# Altium schematic sheet STO.SchDoc(STO)
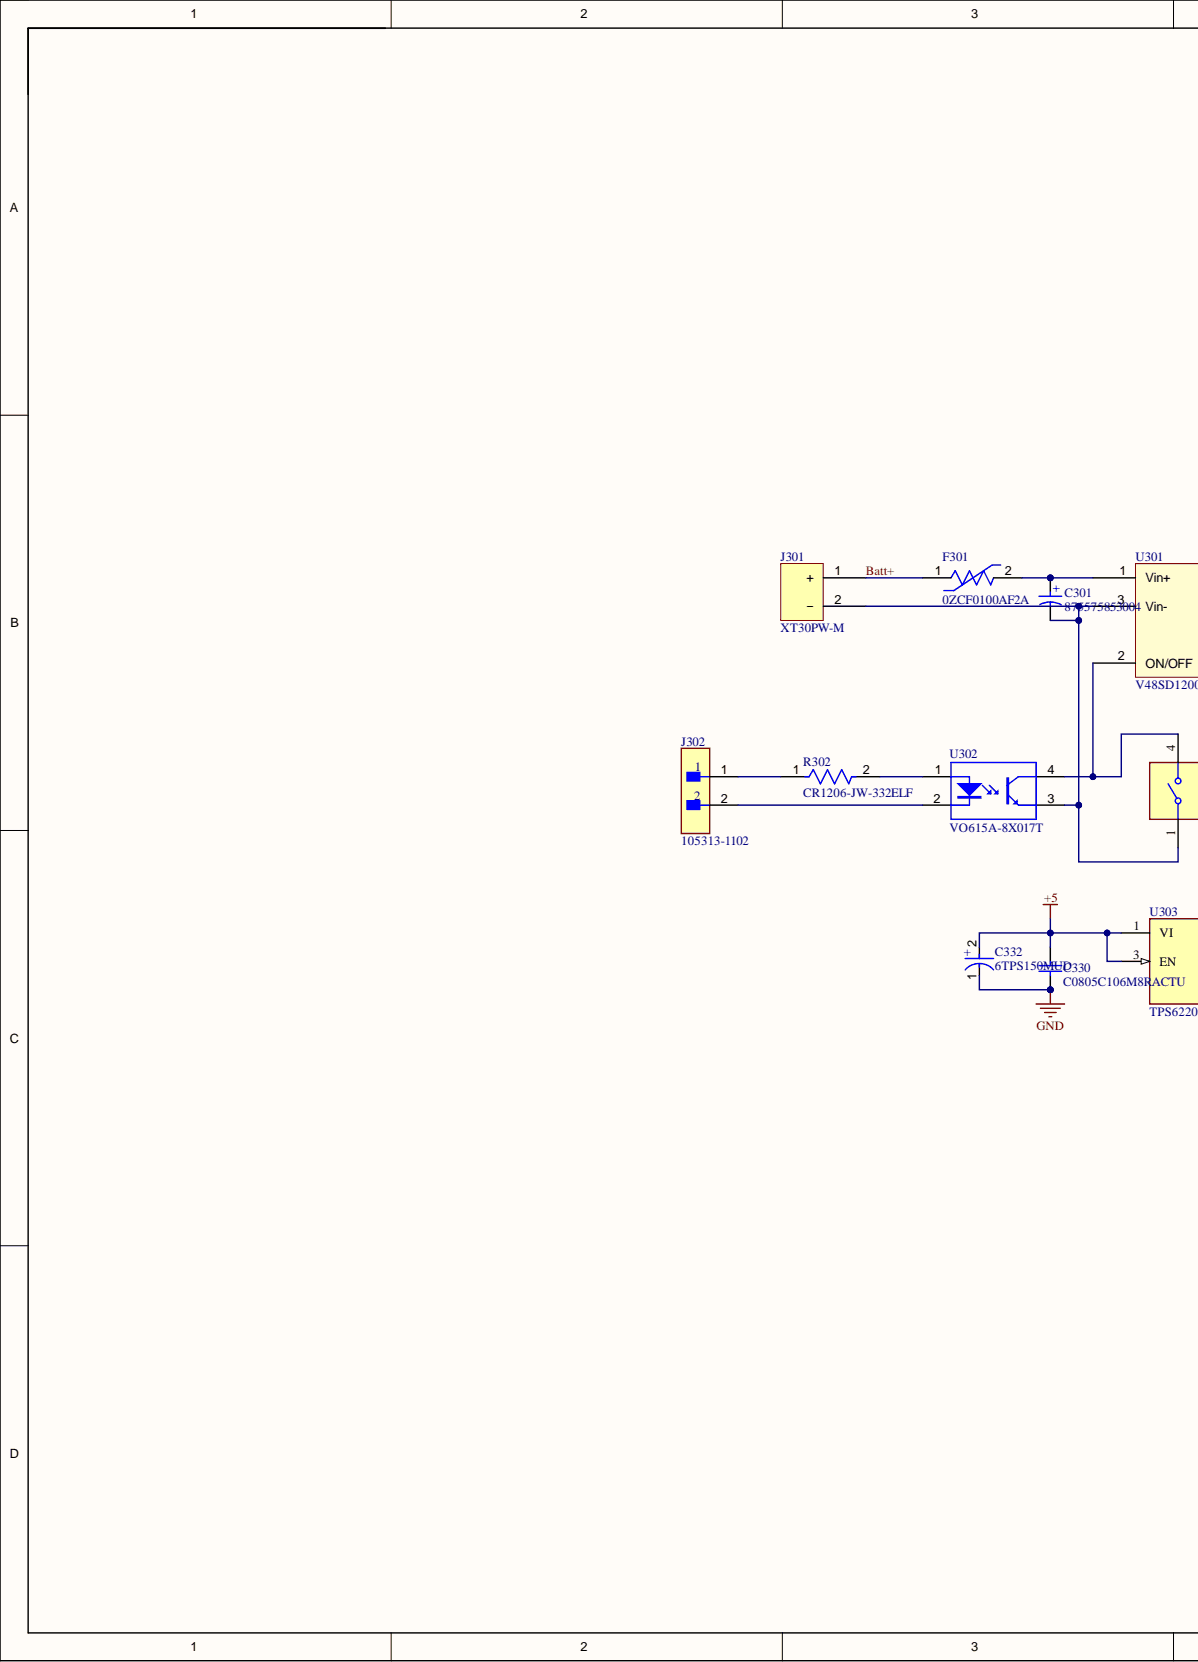
[diagram p0: STO.SchDoc, left]
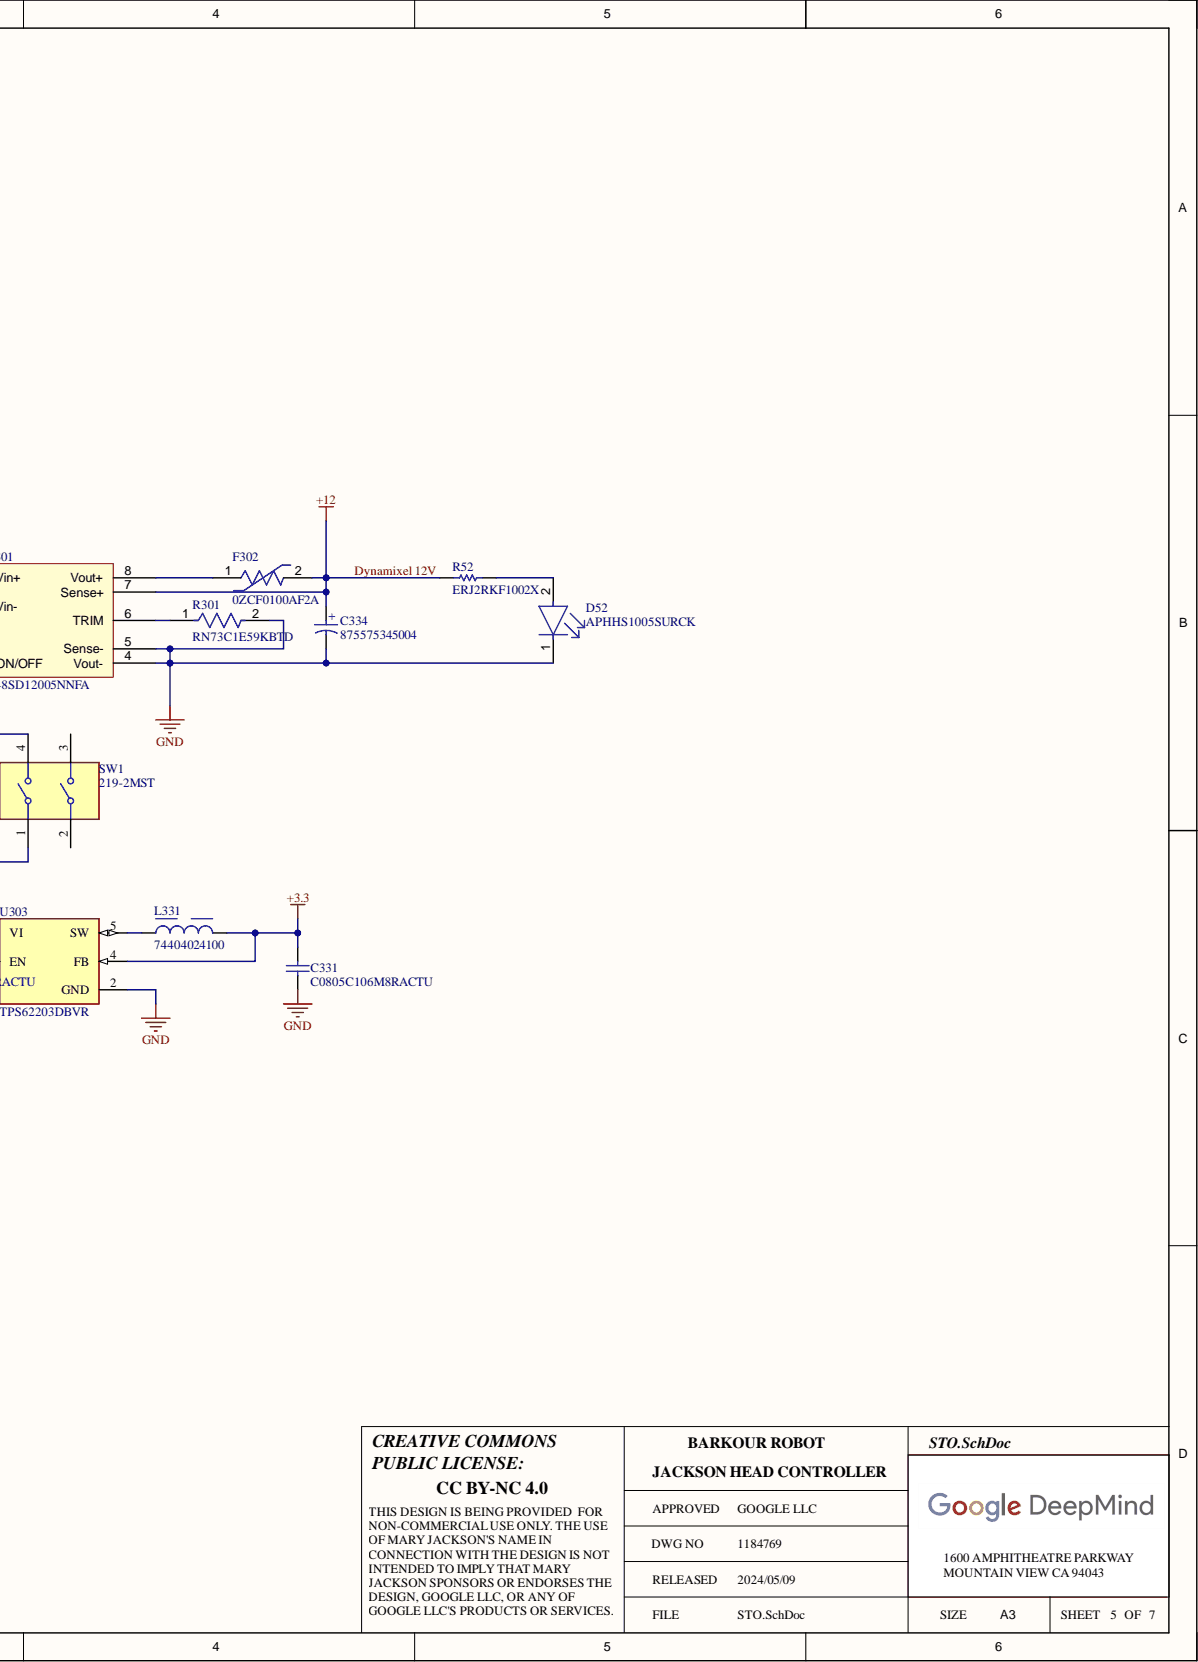
[diagram p1: STO.SchDoc, right]

## Parts
C301  875575853004  [WCAP-HSG5_D8H10.5]
C330  C0805C106M8RACTU  [CAPC2013X140X50NL20T25]
C331  C0805C106M8RACTU  [CAPC2013X140X50NL20T25]
C332  6TPS150MUD  [FP-POSCAP-B1S-MFG]
C334  875575345004  [WCAP-HSG5_D6.3H7.7]
D52  APHHS1005SURCK  [FP-APHHS1005SURCK-MFG]
F301  0ZCF0100AF2A  [FP-0ZCF0100AF2A-MFG]
F302  0ZCF0100AF2A  [FP-0ZCF0100AF2A-MFG]
J301  XT30PW-M  [XT30PW_M]
J302  105313-1102  [FP-105313-1102-MFG]
L331  74404024100  [WE-LQS_2512]
R52  ERJ2RKF1002X  [RESC1005X40X25LL05T05]
R301  RN73C1E59KBTD  [FP-RN731E-MFG]
R302  CR1206-JW-332ELF  [FP-CR1206-IPC_B]
SW1  219-2MST  [FP-219-2MST-MFG]
U301  V48SD12005NNFA  [1/16 Brick]
U302  VO615A-8X017T  [VISH-VO615A-XX017T_V]
U303  TPS62203DBVR  [FP-DBV0005A-IPC_B]

## Nets
+3.3: C331.2 L331.2 U303.4(FB)
+5: C330.1 C332.2 U303.1(VI) U303.3(EN)
GND: C330.2 C331.1 C332.1 C334.2 D52.1 R301.2 U301.4(Vout-) U301.5(Sense-) U303.2(GND)
Batt+: F301.1 J301.1
Dynamixel 12V: C334.1 F302.2 R52.2 U301.7(Sense+)
NetC301_1: C301.1 F301.2 U301.1(Vin+)
NetC301_2: C301.2 J301.2 SW1.1 U301.3(Vin-) U302.3
NetD52_2: D52.2 R52.1
NetF302_1: F302.1 U301.8(Vout+)
NetJ302_1: J302.1 R302.1
NetJ302_2: J302.2 U302.2
NetL331_1: L331.1 U303.5(SW)
NetR301_1: R301.1 U301.6(TRIM)
NetR302_2: R302.2 U302.1
NetSW1_4: SW1.4 U301.2(ON/OFF) U302.4

Not connected: SW1 2 3

## Library data of the parts
C301 = CMP-03913-134709168-1: 875575853004 Cap, 47Uf, 63V, Alu Elec, Hybrid, Smd Rohs Compliant: Yes; Capacitance: 47µF; ESR (Equivalent Series Resistance): 40mΩ; Leakage Current: 29.6µA; Ripple Current: 1.7A; Component Kind: Standard; Diameter: 8mm; Length: 10.5mm; Library Name: Altium Content Vault; Max Operating Temperature: 105°C; Min Operating Temperature: -55°C; NexarPartId: 134709168; RoHS: Compliant; Voltage Rating: 63V; Width: 8.3mm
C330 C331 = CMP-2007-03245-1: Manufacturer: Kemet; Component Kind: Standard; Library Name: Altium Content Vault; Part Number: C0805C106M8RACTU
C332 = CMP-05428-000345-1: Molded Tantalum Polymer Capacitor 150uF 20% 6.3V life 2000Hours SMD 1411; Component Kind: Standard; Library Name: Altium Content Vault; RoHS Compliant: Yes
C334 = CMP-03913-134709149-1: 875575345004 Cap, 220Uf, 16V, Alu Elec, Hybrid, Smd Rohs Compliant: Yes; Capacitance: 220µF; ESR (Equivalent Series Resistance): 30mΩ; Leakage Current: 35.2µA; Ripple Current: 2A; Component Kind: Standard; Diameter: 6.3mm; Length: 7.7mm; Library Name: Altium Content Vault; Max Operating Temperature: 105°C; Min Operating Temperature: -55°C; NexarPartId: 134709149; RoHS: Compliant; Voltage Rating: 16V; Width: 6.5mm
D52 = CMP-2000-05787-2: LED RED CLEAR CHIP SMD; Dominant Wavelength: 630nm; Forward Current: 20mA; Forward Voltage: 1.95V; Luminous Intensity: 220mcd; Number of LEDs: 1; Viewing Angle: 120°; Wavelength: 635nm; Case Code (Imperial): 0402; Case Code (Metric): 1005; Case/Package: 0402; Color: Red; Component Kind: Standard; Height: 0.6mm; Illumination Color: Red; Lead Free: Lead Free; Length: 1mm; Lens Color: Clear; Lens Style: Clear; Library Name: Altium Content Vault; Max Operating Temperature: 85°C; Min Operating Temperature: -40°C; Mount: Surface Mount; Number of Pins: 2; Package Quantity: 2000; Packaging: Tape and Reel; Test Current: 20mA; Width: 0.5mm
F301 F302 = CMP-16043-000014-1: PTC RESET FUSE 60V 1A 2920; Max Current Rating: 100A; Time to Trip: 0.5s; Trip Current: 2A; Case Code (Imperial): 2920; Case Code (Metric): 7351; Component Kind: Standard; Hold Current: 1A; Length: 0.29inch; Library Name: Altium Content Vault; Mount: Surface Mount; Packaging: Tape and Reel; RoHS Compliant: Yes; Thickness: 0.067inch; Width: 0.202inch
J301 = XT30PW-M: Amass XT30 RA TH male header; Component Kind: Standard; Manufacturer: Amass; Manufacturer Part Number: XT30PW-M; Library Name: DMR_common_lib.SchLib
J302 = CMP-04776-000341-1: CONN HEADER R/A 2POS 2.5MM; Component Kind: Standard; Library Name: Altium Content Vault
L331 = CMP-1354-00007-2: NexarPartId: 46948232; Core Material: Ferrite; Current Rating: 650mA; DC Resistance (DCR): 430mΩ; Inductance: 10µH; Inductance Tolerance: 20%; Max DC Current: 470mA; Saturation Current: 850mA; Self Resonant Frequency: 30MHz; Shielding: Shielded; Test Frequency: 1MHz; Ambient Temperature Range High: 85°C; Ambient Temperature Range Low: -40°C; Case/Package: Nonstandard; Component Kind: Standard; Composition: Wirewound; Height: 1.2mm; Height - Seated (Max): 1.1938mm; Length: 2.5mm; Library Name: Altium Content Vault; Max Operating Temperature: 125°C; Min Operating Temperature: -40°C; Mount: Surface Mount; Packaging: Tape & Reel (TR); REACH SVHC: Unknown; RMS Current (Irms): 470mA; RoHS: Compliant; Termination: SMD/SMT; Tolerance: 20%; Weight: 32.006612mg; Width: 2mm
R52 = CMP-2000-07588-1: Chip Resistor, 10 KOhm, +/- 1%, 0.1 W, -55 to 155 degC, 0402 (1005 Metric), RoHS, Tape and Reel; Manufacturer: Panasonic; Case\/Package: 0402; Max Power Dissipation: 100mW; Resistance: 10KOhm; Temperature Coefficient: 100ppm\/degreesC; Voltage Rating: 50V; Case Code (Imperial): 0402; Case Code (Metric): 1005; Component Kind: Standard; Composition: ThickFilm; Contact Plating: Tin; Datasheet URL: https://industrial.panasonic.com/cdbs/www-data/pdf/RDA0000/AOA0000C304.pdf; Datasheet Version: 02/2017; Depth: 0.5mm; Height: 0.35mm; Lead Free: LeadFree; Length: 1mm; Library Name: Altium Content Vault; Manufacturer URL: http://www.panasonic.com/; Max Operating Temperature: 155degC; Military Standard: Not; Min Operating Temperature: -55degC; Mount: SurfaceMount; Mounting Technology: SurfaceMount; Number of Pins: 2; Number of Terminations: 2; Package Description: 2-Pin Surface Mount Device, Body 1 x 0.5 mm; Package Quantity: 1; Package Reference: 402; Packaging: TapeandReel; Power Rating: 100mW; Radiation Hardening: No; REACH SVHC: NoSVHC; RoHSCompliant: True; Termination: SMD/SMT; Tolerance: 1%; Voltage Rating (DC): 50V; Width: 0.02inch
R301 = CMP-03211-010675-1: Res Thin Film 0402 59KΩ 0.1% 1/16W 10ppm/°C Molded SMD; Manufacturer: TE Connectivity; Power Rating: 0.063W; Voltage Rating: 25V; Automotive: No; Category: Res; Component Kind: Standard; ComponentLink2Description: Datasheet; ComponentLink2URL: https://www.te.com/commerce/DocumentDelivery/DDEController?Action=showdoc&DocId=Data+Sheet%7F1773270%7FP%7Fpdf%7FEnglish%7FENG_DS_1773270_P.pdf%7F1-1879132-6; Composition: Thin Film; Device Class L1: Passive Components; Device Class L2: Resistors; Device Class L3: Chip SMD Resistors; Height: 0.35mm; Ipc Land Pattern Name: RESC10050X30; Lead Free: Yes; Library Name: Altium Content Vault; Manufacturer Part Number: RN73C1E59KBTD; Octopart: https://octopart.com/rn73c1e59kbtd-te+connectivity-76703336; octopart_url: https://octopart.com/rn73c1e59kbtd-te+connectivity-76703336; Package: RN731E; packaging: 5000 Reel; Resistance: 59KΩ; RoHS: TRUE; Series: RN73; Temperature: -55°C to +155°C; Temperature Coefficient: 10ppm/°C; Temperature Range High: +155°C; Temperature Range Low: -55°C; Tolerance: 0.1%; Value: 59KΩ
R302 = CMP-07231-005113-1: Thick Film Chip Resistors 1206 3.3kΩ 0.25W 5% 200ppm/°C; Max Power Dissipation: 250mW; Resistance: 3.3kR; Voltage Rating: 200V; Case Code (Imperial): 1206; Case Code (Metric): 3216; Case/Package: 1206; Component Kind: Standard; Composition: Thick Film; Contact Plating: Tin; Depth: 1.6mm; Features: Moisture Resistant; Height: 0.03inch; Length: 3.2mm; Library Name: Altium Content Vault; Max Operating Temperature: 155°C; Military Standard: Not; Min Operating Temperature: -55°C; Mount: Surface Mount; Number of Terminations: 2; Package Quantity: 5000; Packaging: Tape and Reel; Power Rating: 250mW; Radiation Hardening: No; Temperature Coefficient: 200ppm/°C; Termination: SMD/SMT; Thickness: 610um; Tolerance: 5%; Width: 1.6mm
SW1 = CMP-07018-000006-1: SWITCH SLIDE DIP SPST 100MA 20V; Actuator Length: 0.25mm; Actuator Type: Slide (Standard); Contact Resistance: 25mR; Electrical Life: 2000Cycles; Number of Positions: 2; Pitch: 0.1inch; Washable: Yes; Component Kind: Standard; Contact Material: Copper; Contact Plating: Gold; Current Rating: 100mA; Depth: 9.91mm; Height: 4.05mm; Length: 0.258inch; Library Name: Altium Content Vault; Max Current Rating: 100mA; Max Operating Temperature: 85°C; Max Voltage Rating (DC): 20V; Mechanical Life: 2000Cycles; Min Operating Temperature: -55°C; Mount: Surface Mount; Orientation: Straight; Package Quantity: 85; Packaging: Rail/Tube; Radiation Hardening: No; RoHS Compliant: Yes; Terminal Pitch: 2.54mm; Termination: Gull Wing; Throw Configuration: SPST; Voltage Rating (DC): 20V
U302 = CMP-2000-07399-1: Optocoupler, Phototransistor Output, High Temperature, 5000 VRMS, -55 to 110 degC, 4-Pin SMD, RoHS, Tape and Reel; Manufacturer: Vishay; Current - DC Forward (If): 60mA; Current - Output / Channel: 50mA; Current Transfer Ratio (Max): 260%@5mA; Current Transfer Ratio (Min): 130%@5mA; Dynamic Catalog: VOS615A,VOS617A,VOS618ASeries; Input Type: DC; Minimum Quantity: 1; Other Names: VO615A-8X017TDKR; Rise / Fall Time (Typ): 3µs,4.7µs; Turn On / Turn Off Time (Typ): 6µs,5µs; Vce Saturation (Max): 300mV; Voltage - Forward (Vf) (Typ): 1.43V; Voltage - Output: 70V; Category: Isolators; Component Kind: Standard; Current - DC Forward (If) (Max): 60mA; Datasheet URL: http://www.vishay.com/docs/81753/vo615a.pdf; Datasheet Version: Rev. 2.2, 09/2013; Family: Optoisolators-Transistor,PhotovoltaicOutput; Library Name: Altium Content Vault; Manufacturer URL: http://www.vishay.com/; Mounting Technology: SurfaceMount; Mounting Type: SurfaceMount; Number of Channels: 1; Operating Temperature: -55°C~110°C; Output Type: Transistor; Package / Case: 4-SMD,GullWing; Package Description: 4-Pin Surface Mount Device, Body 6.5 x 4.58 mm, Pitch 2.54 mm; Package Reference: VO615A-XX017T; Package/Case: 4-SMD,GullWing; Packaging: Digi-Reel®; Quantity Available: Tape&Reel(TR)AlternatePackaging; Standard Package: 1; Supplier Device Package: 4-SMD; Voltage - Isolation: 5000Vrms; Voltage - Output (Max): 70V
U303 = CMP-04918-000656-1: IC REG BUCK 3.3V 300MA SOT23-5; Efficiency: 95%; Max Input Voltage: 6V; Min Input Voltage: 2.5V; Nominal Supply Current: 15uA; Number of Outputs: 1; Output Configuration: Positive; Output Type: Fixed; Switching Frequency: 1MHz; Synchronous Rectifier: Yes; Case/Package: SOT-23-5; Component Kind: Standard; Contact Plating: Gold; Lead Free: Lead Free; Library Name: Altium Content Vault; Max Operating Temperature: 85°C; Max Output Current: 300mA; Min Operating Temperature: -40°C; Min Output Voltage: 3.3V; Min Supply Voltage: 2.5V; Mount: Surface Mount; Number of Pins: 5; Operating Supply Voltage: 6V; Output Current: 300mA; Output Voltage: 3.3V; Package Quantity: 3000; Packaging: Tape and Reel; Quiescent Current: 15uA; Radiation Hardening: No; RoHS Compliant: Yes; Series: -; Supply Current: 15uA
U301 = V48SD12005NNFA: Component Kind: Standard; Library Name: Schlib1.SchLib
